# Altium schematic sheet 3_power.SchDoc
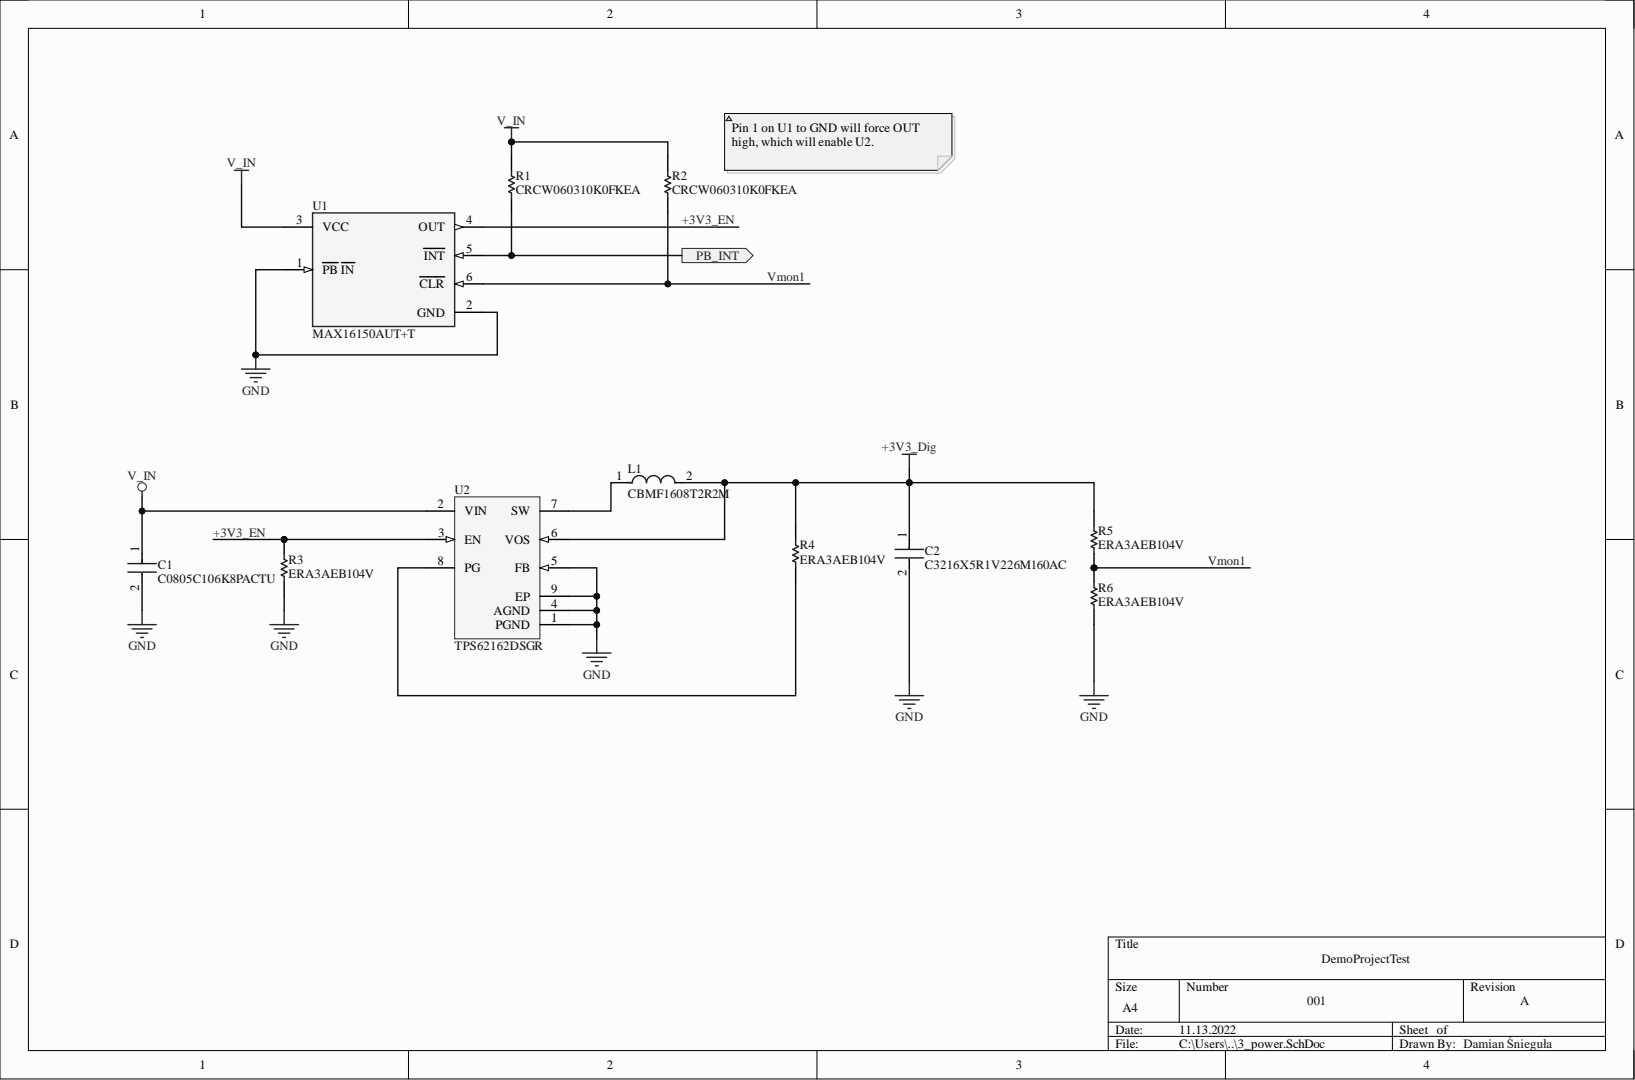
[diagram p0: 3_power.SchDoc]

## Parts
C1  C0805C106K8PACTU  [FP-C0805C-DG-MFG]
C2  C3216X5R1V226M160AC  [FP-C3216-160-0_2-IPC_B]
L1  CBMF1608T2R2M  [FP-CBMF1608-MFG]
R1  CRCW060310K0FKEA  [RESC1609X50X30NL8T20]
R2  CRCW060310K0FKEA  [RESC1609X50X30NL8T20]
R3  ERA3AEB104V  [RESC1608X55X30ML20T20]
R4  ERA3AEB104V  [RESC1608X55X30ML20T20]
R5  ERA3AEB104V  [RESC1608X55X30ML20T20]
R6  ERA3AEB104V  [RESC1608X55X30ML20T20]
U1  MAX16150AUT+T  [FP-U6-1-MFG]
U2  TPS62162DSGR  [DSG0008A_V]

## Ports
PB_INT

## Nets
+3V3_Dig: C2.1 L1.2 R4.2 R5.2 U2.6(VOS)
GND: C1.2 C2.2 R3.1 R6.1 U1.1(PB_IN) U1.2(GND) U2.1(PGND) U2.4(AGND) U2.5(FB) U2.9(EP)
V_IN: C1.1 R1.2 R2.2 U1.3(VCC) U2.2(VIN)
+3V3_EN: R3.2 U1.4(OUT) U2.3(EN)
PB_INT: R1.1 U1.5(INT) ; port PB_INT
Vmon1: R2.1 R5.1 R6.2 U1.6(CLR)
NetL1_1: L1.1 U2.7(SW)
NetR4_1: R4.1 U2.8(PG)

## Library data of the parts
C1 = CMP-2007-03243-2: CAP CER 10UF 10V X5R 0805; 3DS Part Number: 220-00001; Manufacturer: Kemet; Material: Ceramic; Temperature Coefficient: 15%; Tolerance: 10%; Automotive: No; Capacitance: 10uF; Category: Cap; Component Kind: Standard; ComponentLink2Description: Datasheet; ComponentLink2URL: https://content.kemet.com/datasheets/KEM_C1006_X5R_SMD.pdf; Device Class L1: Passive Components; Device Class L2: Capacitors; Device Class L3: Ceramic Capacitors; Digikey Description: CAP CER 10UF 10V X5R 0805; Height: 1.4mm; Ipc Land Pattern Name: CAPC200125X125; Lead Free: Yes; Library Name: Altium Content Vault; Manufacturer Part Number: C0805C106K8PACTU; Mouser Description: CAP CER 10UF 10V X5R 0805; Octopart: https://octopart.com/c0805c106k8pactu-kemet-98697; Package: C0805C-DG; RoHS: TRUE; Temperature: -55°C to +85°C; Temperature Characteristic: X5R; Temperature Range High: +85°C; Temperature Range Low: -55°C; Value: 10uF; Voltage: 10V; Voltage Rating: 10V
C2 = CMP-2000-05398-2: Multilayer Ceramic Capacitors 22uF ±20% 35V X5R SMD 1206; Capacitance: 22uF; Case Code (Imperial): 1206; Case Code (Metric): 3216; Case/Package: 1206; Component Kind: Standard; Construction: Flat; Depth: 1.6mm; Dielectric: X5R; Dielectric Material: Ceramic; Height: 1.6mm; Lead Free: Lead Free; Length: 3.2mm; Library Name: Altium Content Vault; Max Operating Temperature: 85°C; Min Operating Temperature: -55°C; Mount: Surface Mount; Package Quantity: 2000; Packaging: Tape and Reel; Radiation Hardening: No; REACH SVHC: No SVHC; Resistor Type: Ceramic; RoHS Compliant: Yes; Series: C; Termination: SMD/SMT; Thickness: 1.6mm; Tolerance: 20%; Voltage: 35V; Voltage Rating: 35V; Voltage Rating (DC): 35V; Weight: 0.000571oz; Width: 0.063inch
L1 = CMP-14484-000379-1: SMD Inductors 2.2uH ±20% 0.56A 0.221Ω 0603; Core Material: Ferrite; Current Rating: 560mA; DC Resistance (DCR): 221mR; Saturation Current: 190mA; Self Resonant Frequency: 80MHz; Shielding: Unshielded; Test Frequency: 7.96MHz; Case Code (Imperial): 0603; Case Code (Metric): 1608; Case/Package: 0603; Component Kind: Standard; DC Current: 560mA; Depth: 1mm; Halogen Free: Halogen Free; Height: 0.8mm; Height - Seated (Max): 0.039inch; Inductance: 2.2uH; Inductance Tolerance: 20%; Lead Free: Lead Free; Length: 1.6mm; Library Name: Altium Content Vault; Max DC Current: 560mA; Max Operating Temperature: 105°C; Military Standard: Not; Min Operating Temperature: -40°C; Mount: Surface Mount; Package Quantity: 1; Packaging: Tape and Reel; Radiation Hardening: No; Resistor Type: Wirewound; RoHS Compliant: Yes; Series: CBMF; Termination: SMD/SMT; Tolerance: 20%; Width: 0.8mm
R1 R2 = CMP-1666-00005-4: Chip Resistor, 10 KOhm, +/- 1%, 100 mW, -55 to 155 degC, 0603 (1608 Metric), RoHS, Tape and Reel; Manufacturer: Vishay Semiconductor; Voltage Rating: 75 V; Component Kind: Standard; ComponentLink1Description: Manufacturer URL; ComponentLink1URL: http://www.vishay.com/; ComponentLink2Description: Datasheet; ComponentLink2URL: https://datasheet.ciiva.com/11809/0900766b80d98afc-11809037.pdf; DatasheetVersion: 06/2012; Height: 0.45 mm; Length: 1.55 mm; Library Name: Altium Content Vault; Max Operating Temperature: 155 degC; Min Operating Temperature: -55 degC; Mounting Technology: Surface Mount; Package Quantity: 5000; PackageDescription: 2-Pin Surface Mount Device, Body 1.575 x 0.85 mm; PackageReference: 0603; Packaging: Tape and Reel; REACH SVHC: Unknown; RoHSCompliant: true
R3-R6 = CMP-2000-00875-1: Manufacturer: Panasonic; Component Kind: Standard; Library Name: Altium Content Vault; Part Number: ERA3AEB104V
U1 = CMP-05723-001129-1: IC SUPERVISOR 1 CHANNEL SOT23-6; Component Kind: Standard; Library Name: Altium Content Vault
U2 = CMP-0323-00316-3: Buck Step Down Regulator with 3 to 17 V Input and 3.3 V Output, -40 to 85 degC, 8-Pin WSON (DSG), Green (RoHS & no Sb/Br); Manufacturer: Texas Instruments; Duty Cycle(Max)(%): 100; Iout(Max)(A): 1; Iq(Typ)(mA): 0.017; Preset Vout(V): 3.3; Rating: Catalog; SubFamily: Step-Down Regulator; Switch Current Limit(Typ)(A): 1.8; Synchronous Rectification: TRUE; Vin(Max)(V): 17; Vin(Min)(V): 3; Code_JEDEC: MO-229; Component Kind: Standard; ComponentLink1Description: Datasheet; ComponentLink1URL: http://www.ti.com/general/docs/lit/getliterature.tsp?genericPartNumber=TPS62162&fileType=pdf; ComponentLink2Description: Package Specification; ComponentLink2URL: http://www.ti.com/litv/pdf/mpds308a; ComponentLink3Description: URL Link1; ComponentLink3URL: Samples URL:http://www.ti.com/product/tps62162#samples; DatasheetVersion: SLVSAM2A; Eco-Plan: Green (RoHS & no Sb/Br); Library Name: Altium Content Vault; Mounting Technology: Surface Mount; PackageDescription: 8-Pin Plastic Small Outline No-Lead, 2 x 2 x 0.8 mm Body, 0.5 mm Pitch, Exposed Pad; PackageReference: DSG0008A; PackageVersion: revB, Oct-2010; PartNumber: TPS62162DSGR; Regulated Outputs(#): 1; Topology: Buck; Vout(Max)(V): 3.3; Vout(Min)(V): 3.3
